# Altium schematic sheet STM32F303CCT6.SchDoc
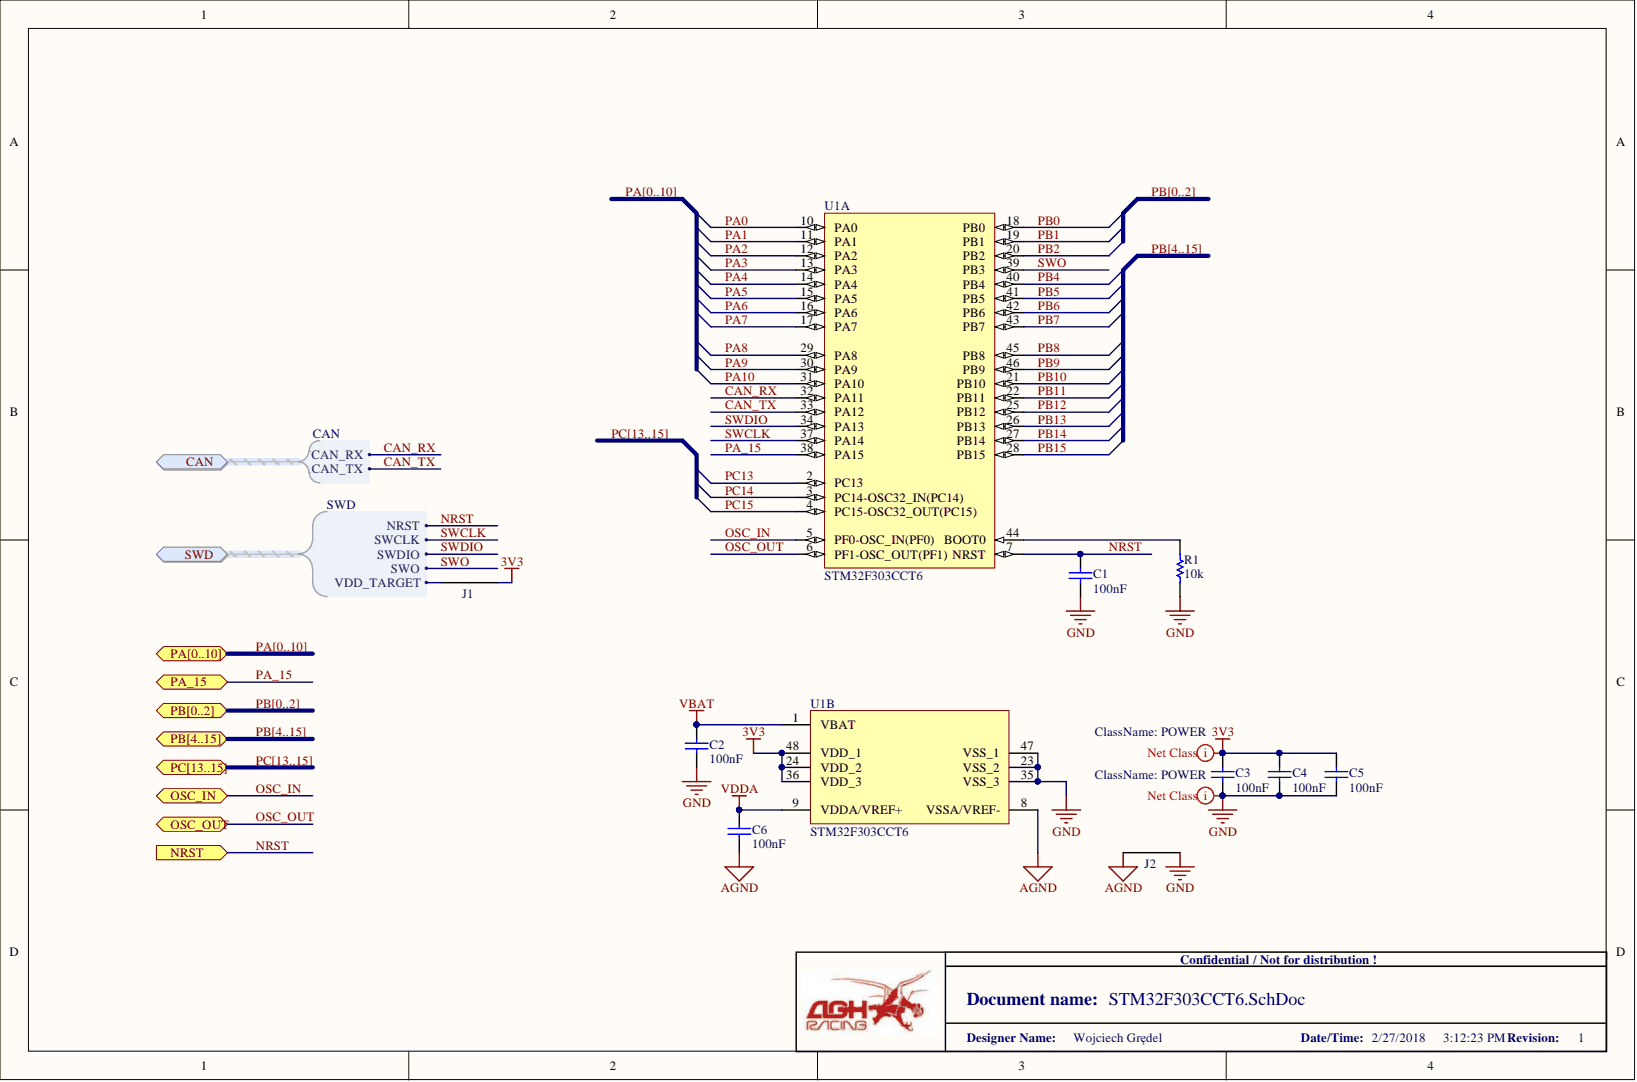
[diagram p0: STM32F303CCT6.SchDoc]

## Parts
C1  value 100nF  [CAP_0603_IPC_MD]  (hidden comment: Cap)
C2  value 100nF  [CAP_0603_IPC_MD]  (hidden comment: Cap)
C3  value 100nF  [CAP_0603_IPC_MD]  (hidden comment: Cap)
C4  value 100nF  [CAP_0603_IPC_MD]  (hidden comment: Cap)
C5  value 100nF  [CAP_0603_IPC_MD]  (hidden comment: Cap)
C6  value 100nF  [CAP_0603_IPC_MD]  (hidden comment: Cap)
J1  NetTie  [NETTIE]  (value not drawn)
J2  NetTie  [NETTIE]  (value not drawn)
R1  value 10k  [RES_0603_IPC_HD]  (hidden comment: Res)
U1  STM32F303CCT6  [LQFP48_IPC_LD]  (parts A,B)

## Ports
CAN, CAN.CAN_RX, CAN.CAN_TX, NRST, OSC_IN, OSC_OUT, PA0, PA1, PA2, PA3, PA4, PA5, PA6, PA7, PA8, PA9, PA10, PA[0..10], PA_15, PB0, PB1, PB2, PB4, PB5, PB6, PB7, PB8, PB9, PB10, PB11, PB12, PB13, PB14, PB15, PB[0..2], PB[4..15], PC13, PC14, PC15, PC[13..15], SWD, SWD.NRST, SWD.SWCLK, SWD.SWDIO, SWD.SWO, SWD.VDD_TARGET

## Nets
3V3: C3.2 C4.2 C5.2 J1.1 U1.24(VDD_2) U1.36(VDD_3) U1.48(VDD_1)
AGND: C6.1 J2.1 U1.8(VSSA/VREF-)
GND: C1.1 C2.1 C3.1 C4.1 C5.1 J2.2 R1.1 U1.23(VSS_2) U1.35(VSS_3) U1.47(VSS_1)
VBAT: C2.2 U1.1(VBAT)
VDDA: C6.2 U1.9(VDDA/VREF+)
CAN_RX: U1.32(PA11) ; port CAN
CAN_TX: U1.33(PA12) ; port CAN
NRST: C1.2 U1.7(NRST) ; port NRST, port SWD
OSC_IN: U1.5(PF0-OSC_IN(PF0)) ; port OSC_IN
OSC_OUT: U1.6(PF1-OSC_OUT(PF1)) ; port OSC_OUT
PA0: U1.10(PA0) ; port PA[0..10]  [also labelled PA]
PA1: U1.11(PA1) ; port PA[0..10]  [also labelled PA]
PA2: U1.12(PA2) ; port PA[0..10]  [also labelled PA]
PA3: U1.13(PA3) ; port PA[0..10]  [also labelled PA]
PA4: U1.14(PA4) ; port PA[0..10]  [also labelled PA]
PA5: U1.15(PA5) ; port PA[0..10]  [also labelled PA]
PA6: U1.16(PA6) ; port PA[0..10]  [also labelled PA]
PA7: U1.17(PA7) ; port PA[0..10]  [also labelled PA]
PA8: U1.29(PA8) ; port PA[0..10]  [also labelled PA]
PA9: U1.30(PA9) ; port PA[0..10]  [also labelled PA]
PA10: U1.31(PA10) ; port PA[0..10]  [also labelled PA]
PA_15: U1.38(PA15) ; port PA_15
PB0: U1.18(PB0) ; port PB[0..2]  [also labelled PB]
PB1: U1.19(PB1) ; port PB[0..2]  [also labelled PB]
PB2: U1.20(PB2) ; port PB[0..2]  [also labelled PB]
PB4: U1.40(PB4) ; port PB[4..15]  [also labelled PB]
PB5: U1.41(PB5) ; port PB[4..15]  [also labelled PB]
PB6: U1.42(PB6) ; port PB[4..15]  [also labelled PB]
PB7: U1.43(PB7) ; port PB[4..15]  [also labelled PB]
PB8: U1.45(PB8) ; port PB[4..15]  [also labelled PB]
PB9: U1.46(PB9) ; port PB[4..15]  [also labelled PB]
PB10: U1.21(PB10) ; port PB[4..15]  [also labelled PB]
PB11: U1.22(PB11) ; port PB[4..15]  [also labelled PB]
PB12: U1.25(PB12) ; port PB[4..15]  [also labelled PB]
PB13: U1.26(PB13) ; port PB[4..15]  [also labelled PB]
PB14: U1.27(PB14) ; port PB[4..15]  [also labelled PB]
PB15: U1.28(PB15) ; port PB[4..15]  [also labelled PB]
PC13: U1.2(PC13) ; port PC[13..15]  [also labelled PC]
PC14: U1.3(PC14-OSC32_IN(PC14)) ; port PC[13..15]  [also labelled PC]
PC15: U1.4(PC15-OSC32_OUT(PC15)) ; port PC[13..15]  [also labelled PC]
SWCLK: U1.37(PA14) ; port SWD
SWD: J1.2 ; port SWD
SWDIO: U1.34(PA13) ; port SWD
SWO: U1.39(PB3) ; port SWD
NetR1_2: R1.2 U1.44(BOOT0)

## Library data of the parts
C1-C6 = Cap: Capacitor; Component Kind: Standard; Library Name: agh_racing_2018.SchLib
R1 = Res: Resistor; Component Kind: Standard; Library Name: agh_racing_2018.SchLib
U1 = STM32F303CCT6: ARM Cortex-M4 32-bit MCU, 256 KB Flash, 40 KB Internal RAM, 37 I/Os, 48-pin LQFP, -40 to 85 degC, Tray; D/A Converter: 2x12-bit (2 Channel); FLASH Size (Prog) (kB): 256; I/Os (High Current): 37; Internal RAM Size (kB): 40; Operating Frequency(F) (Processor speed) (MHz): 72; Supply Voltage(Vcc) min (V): 2; Component Kind: Standard; ComponentLink1Description: Manufacturer URL; ComponentLink1URL: http://www.st.com/web/en/home.html; ComponentLink2Description: Datasheet; ComponentLink2URL: http://www.st.com/st-web-ui/static/active/en/resource/technical/document/datasheet/DM00058181.pdf; ComponentLink3Description: Package Specification; ComponentLink3URL: http://www.st.com/st-web-ui/static/active/en/resource/technical/document/datasheet/DM00058181.pdf; Core: ARM Cortex-M4; DatasheetVersion: Rev. 6; Mounting Technology: Surface Mount; PackageDescription: 48-Pin Low Profile Quad Flat Package, 7 x 7 mm Body, 0.5 mm Pitch; PackageReference: LQFP48; PackageVersion: Rev. 6, 06/2013; Packing: Tray; PartNumber: STM32F303CCT6; Supplier 1: Digi-Key; Supplier 2: Newark; Supplier 3: Newark; Supplier 4: Mouser; Supplier Part Number 1: 497-13318-ND; Supplier Part Number 2: 46W2192; Supplier Part Number 3: 66W7286; Supplier Part Number 4: 511-STM32F303CCT6; Library Name: agh_racing_2018.SchLib
J1 J2 = NetTie: Component Kind: Net Tie
  J1: Library Name: Schematic Library.SchLib
  J2: Library Name: agh_racing_2018.SchLib
